# Altium schematic sheet main.SchDoc(main)
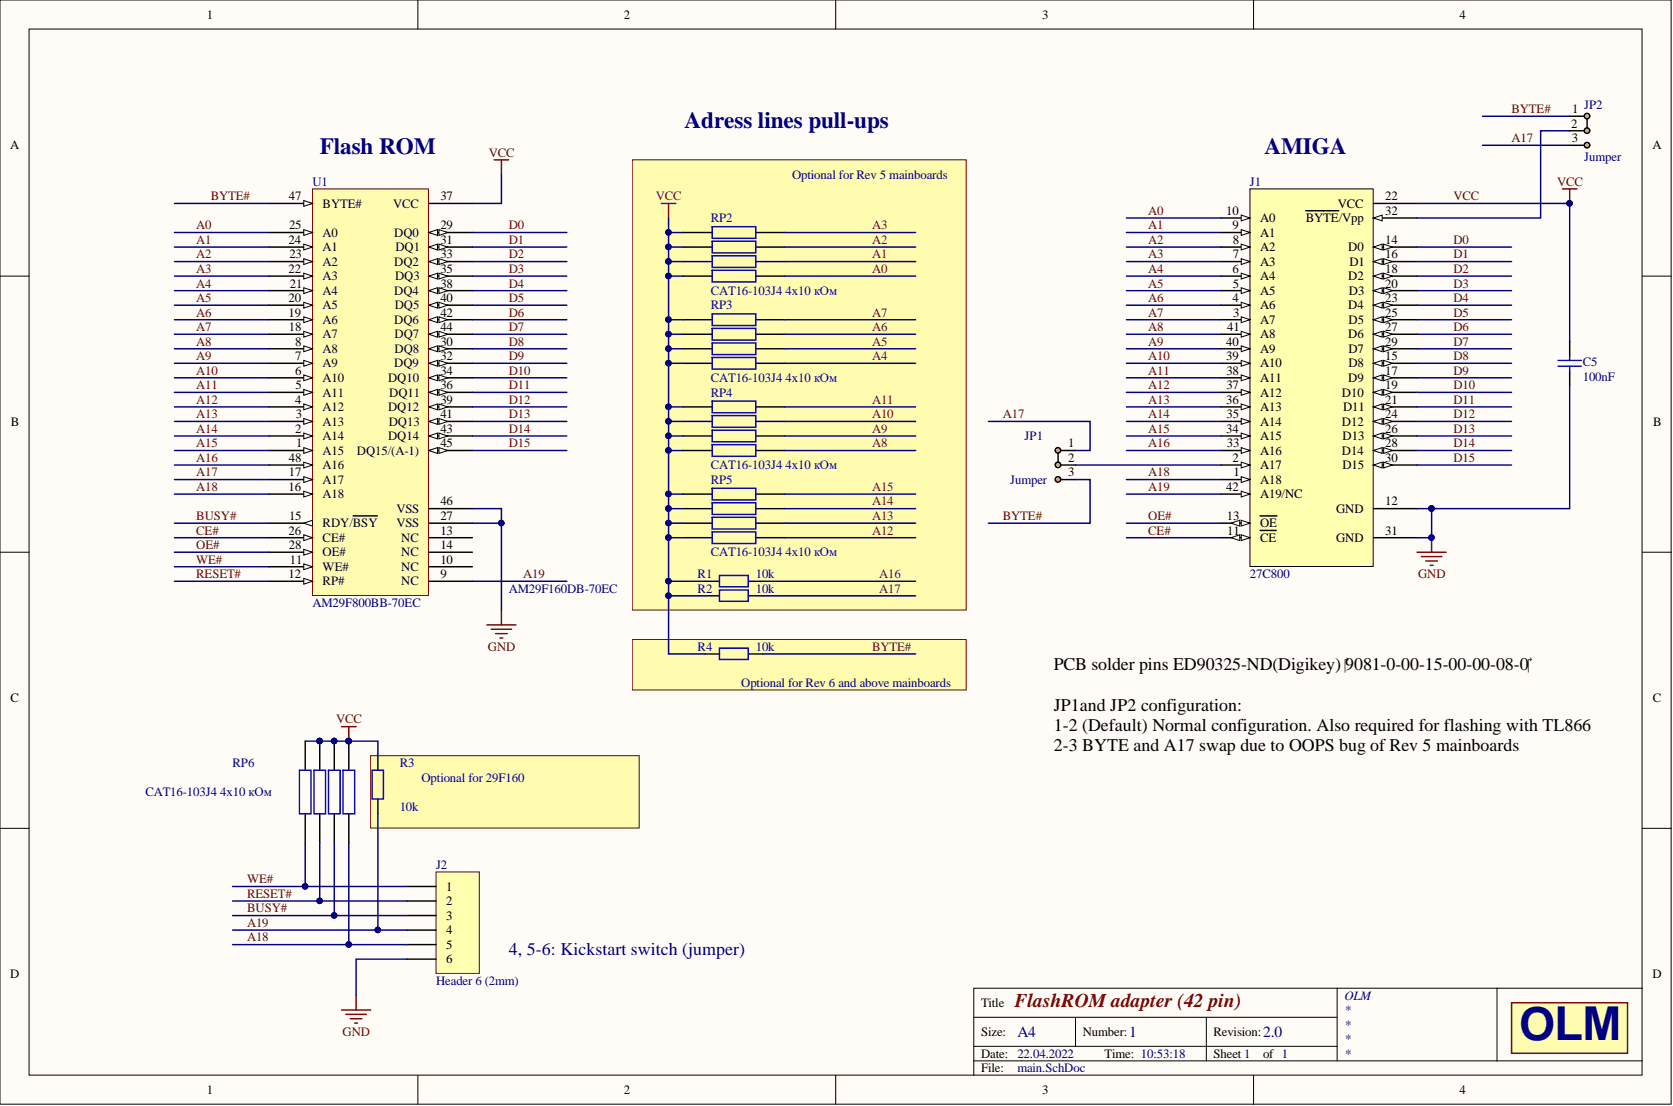
[diagram p0: main.SchDoc]

## Parts
C5  value 100nF  [SM/C_0603]  (hidden comment: Cap)
J1  27C800  [Header DIP42]
J2  Header 6 (2mm)  [PLS2-6]
JP1  Jumper  [Jumper-3-0.7x1.2-0.9mm]
JP2  Jumper  [Jumper-3-0.7x1.2-0.9mm]
R1  value 10k  [SM/R_0603]  (hidden comment: Резистор)
R2  value 10k  [SM/R_0603]  (hidden comment: Резистор)
R3  value 10k  [SM/R_0603]  (hidden comment: Резистор)
R4  value 10k  [SM/R_0603]  (hidden comment: Резистор)
RP2  value CAT16-103J4 4х10 кОм  [RES_PACK_CAT16]  (hidden comment: Резисторная сборка)
RP3  value CAT16-103J4 4х10 кОм  [RES_PACK_CAT16]  (hidden comment: Резисторная сборка)
RP4  value CAT16-103J4 4х10 кОм  [RES_PACK_CAT16]  (hidden comment: Резисторная сборка)
RP5  value CAT16-103J4 4х10 кОм  [RES_PACK_CAT16]  (hidden comment: Резисторная сборка)
RP6  value CAT16-103J4 4х10 кОм  [RES_PACK_CAT16]  (hidden comment: Резисторная сборка)
U1  AM29F800BB-70EC  [TSOP-48]

## Nets
GND: C5.2 J1.12(GND) J1.31(GND) J2.6 U1.27(VSS) U1.46(VSS)
A0: J1.10(A0) RP2.1 U1.25(A0)
A1: J1.9(A1) RP2.2 U1.24(A1)
A2: J1.8(A2) RP2.3 U1.23(A2)
A3: J1.7(A3) RP2.4 U1.22(A3)
A4: J1.6(A4) RP3.1 U1.21(A4)
A5: J1.5(A5) RP3.2 U1.20(A5)
A6: J1.4(A6) RP3.3 U1.19(A6)
A7: J1.3(A7) RP3.4 U1.18(A7)
A8: J1.41(A8) RP4.1 U1.8(A8)
A9: J1.40(A9) RP4.2 U1.7(A9)
A10: J1.39(A10) RP4.3 U1.6(A10)
A11: J1.38(A11) RP4.4 U1.5(A11)
A12: J1.37(A12) RP5.1 U1.4(A12)
A13: J1.36(A13) RP5.2 U1.3(A13)
A14: J1.35(A14) RP5.3 U1.2(A14)
A15: J1.34(A15) RP5.4 U1.1(A15)
A16: J1.33(A16) R1.2 U1.48(A16)
A17: JP1.1 JP2.3 R2.2 U1.17(A17)
A18: J1.1(A18) J2.5 RP6.1 U1.16(A18)
A19: J1.42(A19/NC) J2.4 R3.2 U1.9(NC)
BUSY#: J2.3 RP6.2 U1.15(RDY/BSY)
BYTE#: JP1.3 JP2.1 R4.2 U1.47(BYTE#)
CE#: J1.11(CE) U1.26(CE#)
D0: J1.14(D0) U1.29(DQ0)
D1: J1.16(D1) U1.31(DQ1)
D2: J1.18(D2) U1.33(DQ2)
D3: J1.20(D3) U1.35(DQ3)
D4: J1.23(D4) U1.38(DQ4)
D5: J1.25(D5) U1.40(DQ5)
D6: J1.27(D6) U1.42(DQ6)
D7: J1.29(D7) U1.44(DQ7)
D8: J1.15(D8) U1.30(DQ8)
D9: J1.17(D9) U1.32(DQ9)
D10: J1.19(D10) U1.34(DQ10)
D11: J1.21(D11) U1.36(DQ11)
D12: J1.24(D12) U1.39(DQ12)
D13: J1.26(D13) U1.41(DQ13)
D14: J1.28(D14) U1.43(DQ14)
D15: J1.30(D15) U1.45(DQ15/(A-1))
OE#: J1.13(OE) U1.28(OE#)
RESET#: J2.2 RP6.3 U1.12(RP#)
VCC: C5.1 J1.22(VCC) R1.1 R2.1 R3.1 R4.1 RP2.5 RP2.6 RP2.7 RP2.8 RP3.5 RP3.6 RP3.7 RP3.8 RP4.5 RP4.6 RP4.7 RP4.8 RP5.5 RP5.6 RP5.7 RP5.8 RP6.5 RP6.6 RP6.7 RP6.8 U1.37(VCC)
WE#: J2.1 RP6.4 U1.11(WE#)
NetJ1_2: J1.2(A17) JP1.2
NetJ1_32: J1.32(BYTE/Vpp) JP2.2

Not connected: U1 10(NC) 13(NC) 14(NC)

## Library data of the parts
C5 = Cap ceramic: CAP-100Nf; Component Kind: Standard; Library Name: New.SCHLIB
J1 = 27C800: 512K x 16 EPROM; Class: Memory; Component Kind: Standard; Library Name: New.SCHLIB; SubClass: EPROM
J2 = Header 6: Header, 6-Pin; Component Kind: Standard; LatestRevisionDate: 17-Jul-2002; LatestRevisionNote: Re-released for DXP Platform.; Library Name: Miscellaneous Connectors.IntLib; Signal Integrity: Connector; Publisher: Altium Limited
JP1 JP2 = Jumper-3: Jumper Wire; Manufacturer: Generic Components; Component Kind: Standard; Library Name: New_home.SCHLIB; Published: 1999
R1-R4 = Res2: Resistor; Component Kind: Standard; LatestRevisionDate: 17-Jul-2002; LatestRevisionNote: Re-released for DXP Platform.; Library Name: Miscellaneous Devices.IntLib; PackageReference: AXIAL-0.4; Published: 8-Jun-2000; Signal Integrity: Res; Simulation: RESISTOR; Publisher: Altium Limited
RP2-RP6 = RES_PACK_4: Component Kind: Standard; Library Name: New.SCHLIB
U1 = AM29F800BB-70EC: 8Mb SMART 5 BOOT BLOCK FLASH MEMORY; Manufacturer: Micron Technology Inc; ManufacturerPN: MT28F800B5WP-8B; CategoryI: Integrated Circuits (ICs); CategoryII: NOR FLASH MEMORY; DesignedBy: Circuitry Parts; SchematicRev: A; CircuitryPartsPN: AM29F800BB-70EC; Component Kind: Standard; DesignedOn: 7/18/2005 3:14:54 PM; Library Name: NOR_FLASH.schlib; MfgURL: http://www.micron.com/; PackageName: TSOP48
